# Altium schematic sheet Sensors.SchDoc(Sensors)
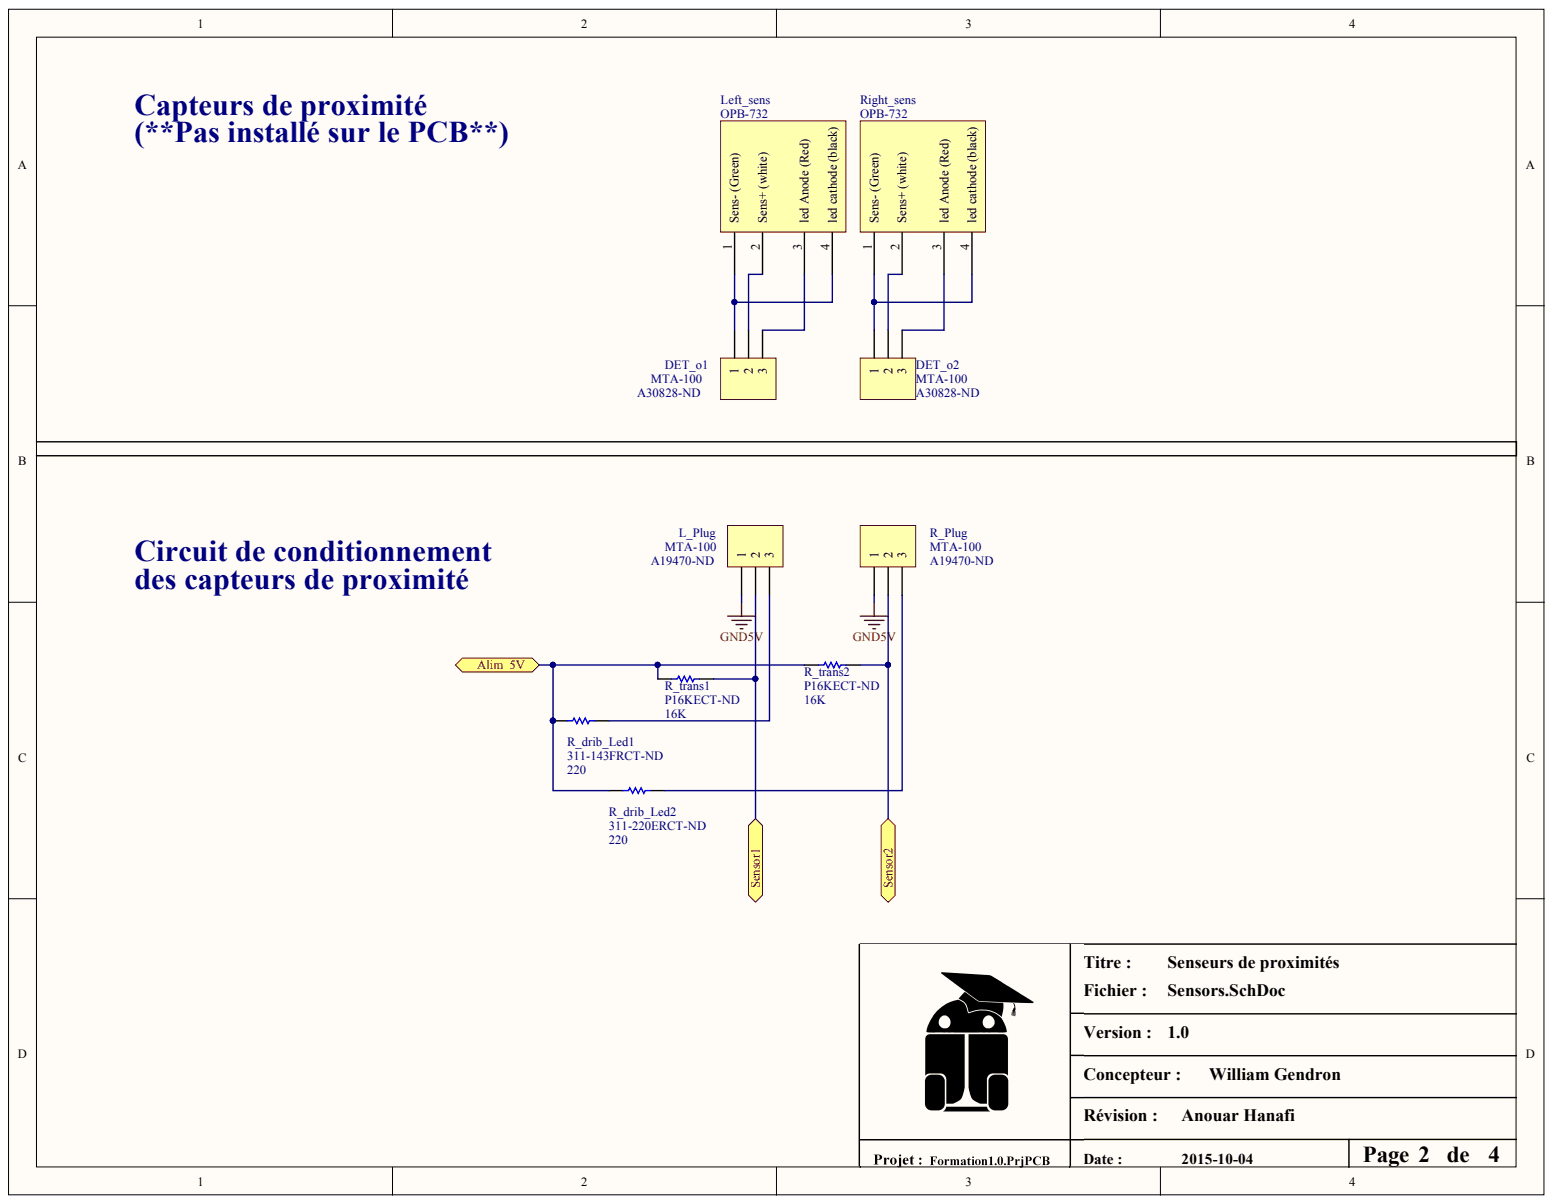
[diagram p0: Sensors.SchDoc]

## Parts
DET_o1  value MTA-100  Supplier Part Number 1: A30828-ND  (hidden comment: Header 3)
DET_o2  value MTA-100  Supplier Part Number 1: A30828-ND  (hidden comment: Header 3)
L_Plug  value MTA-100  Supplier Part Number 1: A19470-ND  [3PIN_254]  (hidden comment: Header 3)
Left_sens  OPB-732
R_drib_Led1  value 220  Supplier part number 1: 311-143FRCT-ND  [C1206]  (hidden comment: 220ohms - 311-220ERCT-ND)
R_drib_Led2  220  [C1206]
R_Plug  value MTA-100  Supplier Part Number 1: A19470-ND  [3PIN_254]  (hidden comment: Header 3)
R_trans1  value 16K  Supplier Part Number 1: P16KECT-ND  [c1206]  (hidden comment: 16k - P16KECT-ND)
R_trans2  value 16K  Supplier Part Number 1: P16KECT-ND  [c1206]  (hidden comment: 16K - P16KECT-ND)
Right_sens  OPB-732

## Ports
ALIM_5V, SENSOR1, SENSOR2

## Nets
GND5V: L_Plug.1 R_Plug.1
NetDET_o1_1: DET_o1.1 Left_sens.3(led_Anode_(Red))
NetDET_o1_2: DET_o1.2 Left_sens.2(Sens+_(white))
NetDET_o1_3: DET_o1.3 Left_sens.1(Sens-_(Green)) Left_sens.4(led_cathode_(black))
NetDET_o2_1: DET_o2.1 Right_sens.3(led_Anode_(Red))
NetDET_o2_2: DET_o2.2 Right_sens.2(Sens+_(white))
NetDET_o2_3: DET_o2.3 Right_sens.1(Sens-_(Green)) Right_sens.4(led_cathode_(black))
NetL_Plug_2: L_Plug.2 R_trans1.1 ; port SENSOR1
NetL_Plug_3: L_Plug.3 R_drib_Led1.2
NetR_drib_Led1_1: R_drib_Led1.1 R_drib_Led2.1 R_trans1.2 R_trans2.2 ; port ALIM_5V
NetR_drib_Led2_2: R_drib_Led2.2 R_Plug.3
NetR_Plug_2: R_Plug.2 R_trans2.1 ; port SENSOR2

## Library data of the parts
DET_o1 DET_o2 L_Plug R_Plug = Header 3: Header, 3-Pin; Component Kind: Standard; Signal Integrity: Connector; Supplier 1: Digi-Key; Library Name: Robocup_LibraryV2_0.IntLib
Left_sens Right_sens = OPB732: Component Kind: Standard; Library Name: light_sensor.SchLib; Supplier 1: Digi-Key; Supplier Part Number 1: 365-1692-ND
R_drib_Led1 R_drib_Led2 R_trans1 R_trans2 = Res1: Resistor; Component Kind: Standard; Library Name: Miscellaneous Devices.IntLib; Signal Integrity: Res; Simulation: RESISTOR; Supplier 1: Digi-Key
  R_drib_Led2: Supplier part number 1: 311-220ERCT-ND; Value: 220
